# Altium schematic sheet S7CAS-PLEIADES-CARTE-MERE_P02_POWER.SchDoc
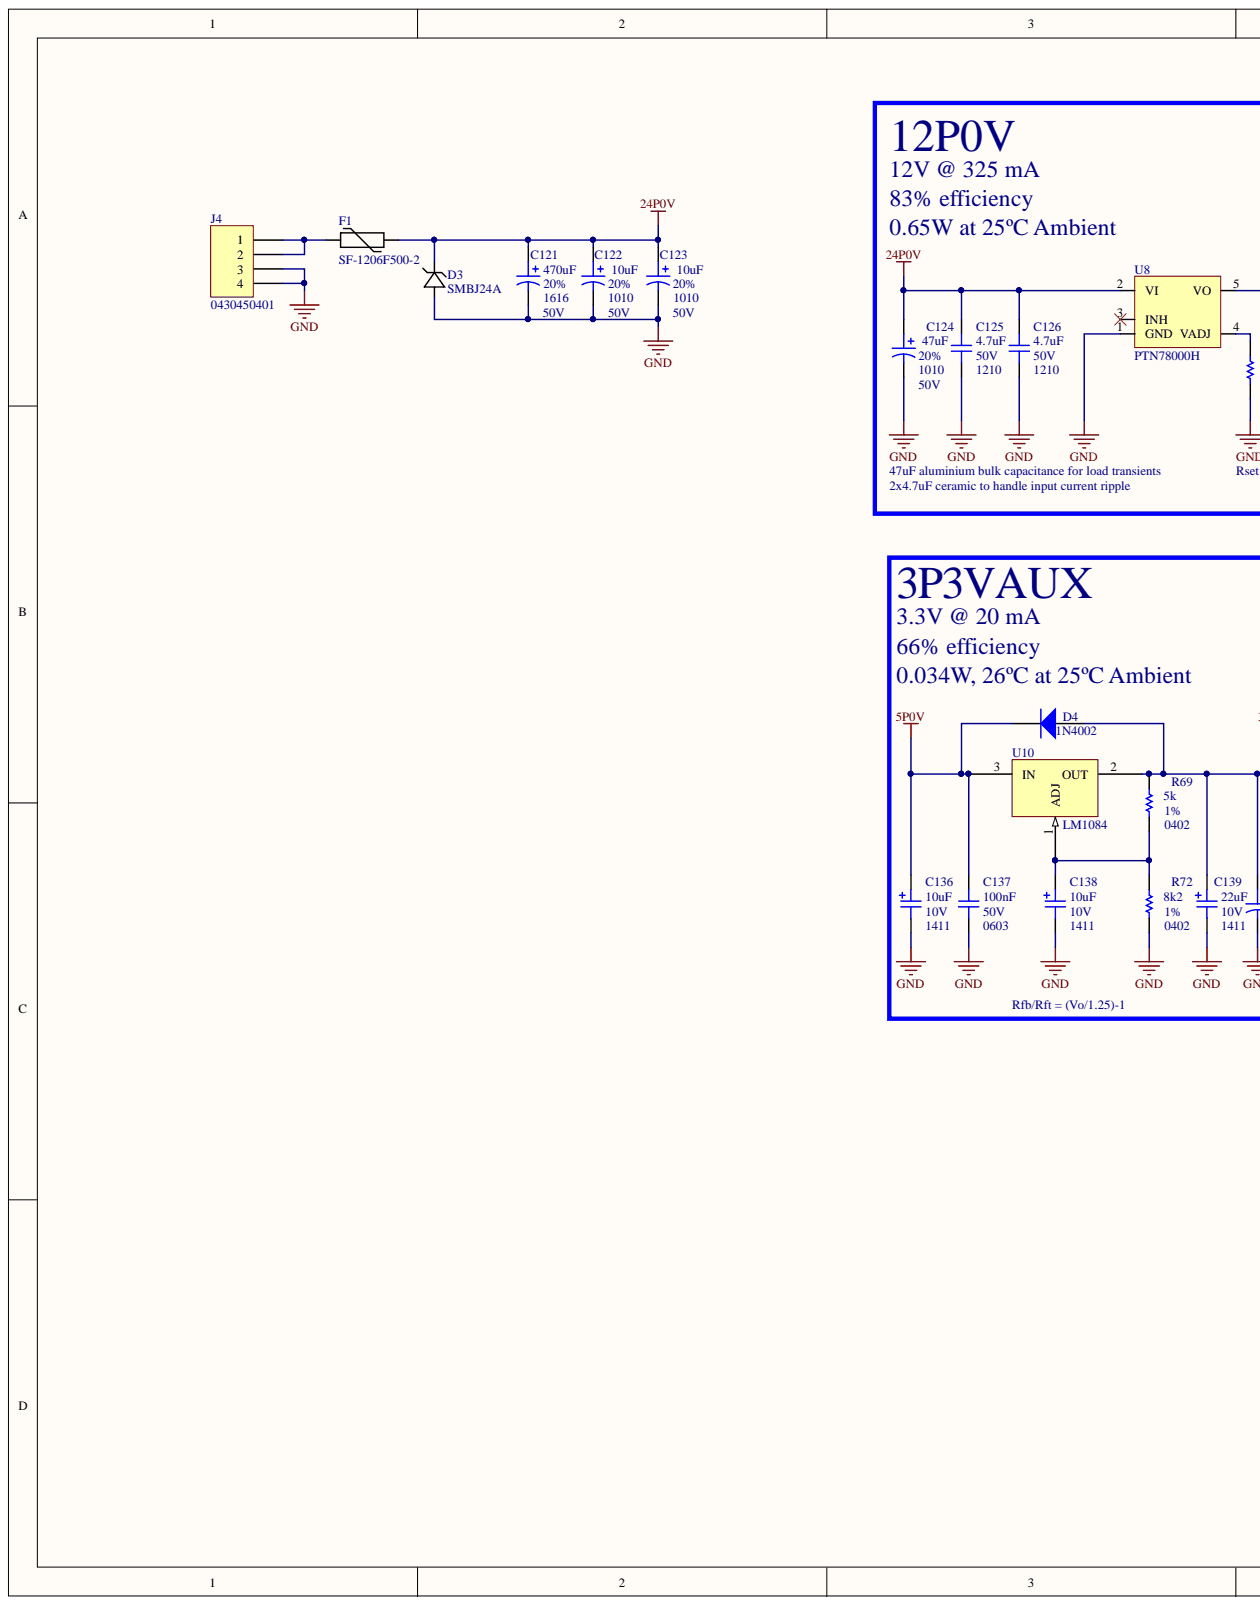
[diagram p0: S7CAS-PLEIADES-CARTE-MERE_P02_POWER.SchDoc, left]
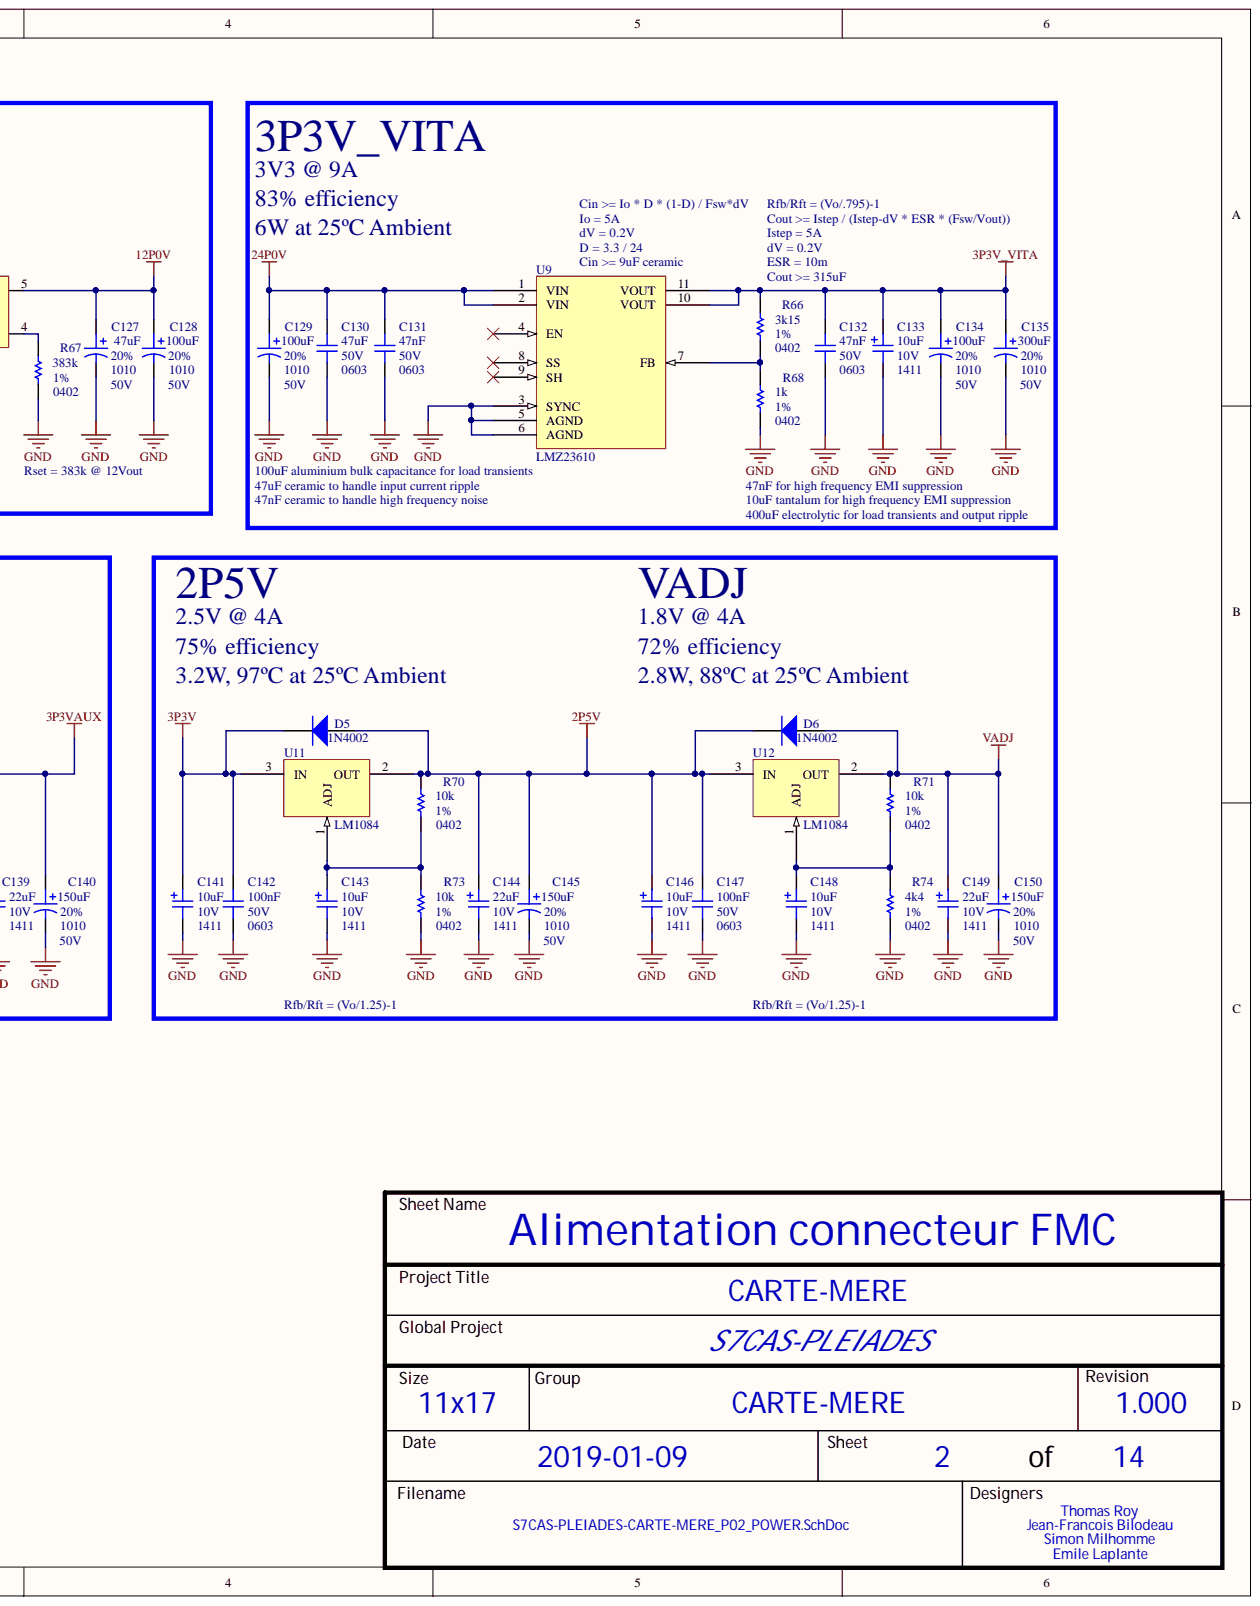
[diagram p1: S7CAS-PLEIADES-CARTE-MERE_P02_POWER.SchDoc, right]

## Parts
C121  value 470uF  Package: 1616  Precision: 20%  Voltage: 50V  [CAP_ALU_1616_vishay]  (hidden comment: MAL214699108E3)
C122  value 10uF  Package: 1010  Precision: 20%  Voltage: 50V  [CAP_ALU_1010_vishay]  (hidden comment: MAL215099101E3)
C123  value 10uF  Package: 1010  Precision: 20%  Voltage: 50V  [CAP_ALU_1010_vishay]  (hidden comment: MAL215099101E3)
C124  value 47uF  Package: 1010  Precision: 20%  Voltage: 50V  [CAP_ALU_1010_vishay]  (hidden comment: MAL215099101E3)
C125  value 4.7uF  Package: 1210  Voltage: 50V  [CAP_1210]  (hidden comment: CAP - 1210)
C126  value 4.7uF  Package: 1210  Voltage: 50V  [CAP_1210]  (hidden comment: CAP - 1210)
C127  value 47uF  Package: 1010  Precision: 20%  Voltage: 50V  [CAP_ALU_1010_vishay]  (hidden comment: MAL215099101E3)
C128  value 100uF  Package: 1010  Precision: 20%  Voltage: 50V  [CAP_ALU_1010_vishay]  (hidden comment: MAL215099101E3)
C129  value 100uF  Package: 1010  Precision: 20%  Voltage: 50V  [CAP_ALU_1010_vishay]  (hidden comment: MAL215099101E3)
C130  value 47uF  Package: 0603  Voltage: 50V  [CAP_0603]  (hidden comment: CAP - 0603)
C131  value 47nF  Package: 0603  Voltage: 50V  [CAP_0603]  (hidden comment: CAP - 0603)
C132  value 47nF  Package: 0603  Voltage: 50V  [CAP_0603]  (hidden comment: CAP - 0603)
C133  value 10uF  Package: 1411  Voltage: 10V  [CAP_TAN_1411(B)]  (hidden comment: CAP - TANTALUM - CASE B)
C134  value 100uF  Package: 1010  Precision: 20%  Voltage: 50V  [CAP_ALU_1010_vishay]  (hidden comment: MAL215099101E3)
C135  value 300uF  Package: 1010  Precision: 20%  Voltage: 50V  [CAP_ALU_1010_vishay]  (hidden comment: MAL215099101E3)
C136  value 10uF  Package: 1411  Voltage: 10V  [CAP_TAN_1411(B)]  (hidden comment: CAP - TANTALUM - CASE B)
C137  value 100nF  Package: 0603  Voltage: 50V  [CAP_0603]  (hidden comment: CAP - 0603)
C138  value 10uF  Package: 1411  Voltage: 10V  [CAP_TAN_1411(B)]  (hidden comment: CAP - TANTALUM - CASE B)
C139  value 22uF  Package: 1411  Voltage: 10V  [CAP_TAN_1411(B)]  (hidden comment: CAP - TANTALUM - CASE B)
C140  value 150uF  Package: 1010  Precision: 20%  Voltage: 50V  [CAP_ALU_1010_vishay]  (hidden comment: MAL215099101E3)
C141  value 10uF  Package: 1411  Voltage: 10V  [CAP_TAN_1411(B)]  (hidden comment: CAP - TANTALUM - CASE B)
C142  value 100nF  Package: 0603  Voltage: 50V  [CAP_0603]  (hidden comment: CAP - 0603)
C143  value 10uF  Package: 1411  Voltage: 10V  [CAP_TAN_1411(B)]  (hidden comment: CAP - TANTALUM - CASE B)
C144  value 22uF  Package: 1411  Voltage: 10V  [CAP_TAN_1411(B)]  (hidden comment: CAP - TANTALUM - CASE B)
C145  value 150uF  Package: 1010  Precision: 20%  Voltage: 50V  [CAP_ALU_1010_vishay]  (hidden comment: MAL215099101E3)
C146  value 10uF  Package: 1411  Voltage: 10V  [CAP_TAN_1411(B)]  (hidden comment: CAP - TANTALUM - CASE B)
C147  value 100nF  Package: 0603  Voltage: 50V  [CAP_0603]  (hidden comment: CAP - 0603)
C148  value 10uF  Package: 1411  Voltage: 10V  [CAP_TAN_1411(B)]  (hidden comment: CAP - TANTALUM - CASE B)
C149  value 22uF  Package: 1411  Voltage: 10V  [CAP_TAN_1411(B)]  (hidden comment: CAP - TANTALUM - CASE B)
C150  value 150uF  Package: 1010  Precision: 20%  Voltage: 50V  [CAP_ALU_1010_vishay]  (hidden comment: MAL215099101E3)
D3  SMBJ24A  [DO-214AA]
D4  1N4002  [DO-41]
D5  1N4002  [DO-41]
D6  1N4002  [DO-41]
F1  SF-1206F500-2  [1206]
J4  0430450401  [0430450401]
R66  value 3k15  Package: 0402  Precision: 1%  [RES_0402]  (hidden comment: RES - 0402)
R67  value 383k  Package: 0402  Precision: 1%  [RES_0402]  (hidden comment: RES - 0402)
R68  value 1k  Package: 0402  Precision: 1%  [RES_0402]  (hidden comment: RES - 0402)
R69  value 5k  Package: 0402  Precision: 1%  [RES_0402]  (hidden comment: RES - 0402)
R70  value 10k  Package: 0402  Precision: 1%  [RES_0402]  (hidden comment: RES - 0402)
R71  value 10k  Package: 0402  Precision: 1%  [RES_0402]  (hidden comment: RES - 0402)
R72  value 8k2  Precision: 1%  [RES_0402]  (hidden comment: RES - 0402)
R73  value 10k  Precision: 1%  [RES_0402]  (hidden comment: RES - 0402)
R74  value 4k4  Precision: 1%  [RES_0402]  (hidden comment: RES - 0402)
U8  PTN78000H  [PTN78000W]
U9  LMZ23610  [NDY0011A]
U10  LM1084  [LM1084-DPAK]
U11  LM1084  [LM1084-DPAK]
U12  LM1084  [LM1084-DPAK]

## Nets
2P5V: C144.2 C145.1 C146.2 C147.2 D5.1 D6.2 R70.2 U11.2 U12.3
3P3V: C141.2 C142.2 D5.2 U11.3
3P3V_VITA: C132.2 C133.2 C134.1 C135.1 R66.2 U9.10(VOUT) U9.11(VOUT)
3P3VAUX: C139.2 C140.1 D4.1 R69.2 U10.2
5P0V: C136.2 C137.2 D4.2 U10.3
12P0V: C127.1 C128.1 U8.5(VO)
24P0V: C121.1 C122.1 C123.1 C124.1 C125.2 C126.2 C129.1 C130.2 C131.2 D3.1 F1.2 U8.2(VI) U9.1(VIN) U9.2(VIN)
GND: C121.2 C122.2 C123.2 C124.2 C125.1 C126.1 C127.2 C128.2 C129.2 C130.1 C131.1 C132.1 C133.1 C134.2 C135.2 C136.1 C137.1 C138.1 C139.1 C140.2 C141.1 C142.1 C143.1 C144.1 C145.2 C146.1 C147.1 C148.1 C149.1 C150.2 D3.2 J4.3 J4.4 R67.1 R68.1 R72.1 R73.1 R74.1 U8.1(GND) U9.3(SYNC) U9.5(AGND) U9.6(AGND)
VADJ: C149.2 C150.1 D6.1 R71.2 U12.2
NetC138_2: C138.2 R69.1 R72.2 U10.1
NetC143_2: C143.2 R70.1 R73.2 U11.1
NetC148_2: C148.2 R71.1 R74.2 U12.1
NetF1_1: F1.1 J4.1 J4.2
NetR66_1: R66.1 R68.2 U9.7(FB)
NetR67_2: R67.2 U8.4(VADJ)

Not connected: U8 3(INH); U9 4(EN) 8(SS) 9(SH)

## Library data of the parts
C121 = CAP_ALU_MAL214699108E3: CAP - ALU 470uF 20% 50V - 1616; Current: 1.3A; Mfg: Vishay Dale; Component Kind: Standard; HelpURL: http://www.vishay.com/docs/28403/146cti.pdf; Library Name: S7CAS_Lib2019_REV1_00.IntLib; Mfg Part Number: MAL214699108E3; Supplier 1: Digikey; Supplier Part Number 1: 4442PHCT-ND; Temperature: -55°C to 125°C; CreatedBy: Jonathan Bouchard
C122 C123 C128 C129 C134 C135 C140 C145 C150 = CAP_ALU_MAL215099101E3: CAP - ALU 100uF 20% 50V - 1010; Current: 490mA; Mfg: Vishay Dale; Component Kind: Standard; HelpURL: http://www.vishay.com/docs/28395/150crz.pdf; Library Name: S7CAS_Lib2019_REV1_00.IntLib; Mfg Part Number: MAL215099101E3; Supplier 1: Digikey; Supplier Part Number 1: 4399PHDKR-ND; Temperature: -55°C to 125°C; CreatedBy: Jonathan Bouchard
C124 C127 = CAP_ALU_MAL215099101E3: CAP - ALU 47uF 20% 50V - 1010; Current: 490mA; Mfg: Vishay Dale; Component Kind: Standard; HelpURL: http://www.vishay.com/docs/28395/150crz.pdf; Library Name: S7CAS_Lib2019_REV1_00.IntLib; Mfg Part Number: MAL215099101E3; Supplier 1: Digikey; Supplier Part Number 1: 4399PHDKR-ND; Temperature: -55°C to 125°C; CreatedBy: Jonathan Bouchard
C125 C126 = CAP - 1210: Precision: 10%; Component Kind: Standard; Description: CAP - 1210; Library Name: S7CAS_Lib2019_REV1_00.IntLib; CreatedBy: Jonathan Bouchard
C130-C132 C137 C142 C147 = CAP - 0603: Precision: 10%; Component Kind: Standard; Description: CAP - 0603; Library Name: S7CAS_Lib2019_REV1_00.IntLib; CreatedBy: Jonathan Bouchard
C133 C136 C138 C139 C141 C143 C144 C146 C148 C149 = CAP_TANT_1411(B): CAP - TANTALUM - 1411; Precision: 10%; Component Kind: Standard; Library Name: S7CAS_Lib2019_REV1_00.IntLib; CreatedBy: Jonathan Bouchard
D3 = SMBJ24A: Imax: 15.5A; Pd: 600W; Vf: 24V; Component Kind: Standard; Library Name: TempLibrary.SchLib
D4-D6 = Diode 1N4002: 1 Amp General Purpose Rectifier; Code_JEDEC: DO-204-AL; Component Kind: Standard; ComponentLink1Description: Manufacturer Link; ComponentLink1URL: http://www.fairchildsemi.com/; ComponentLink2Description: Datasheet; ComponentLink2URL: http://www.fairchildsemi.com/ds/1N%2F1N4001.pdf; DatasheetVersion: 1998; LatestRevisionDate: 17-Jul-2002; LatestRevisionNote: Re-released for DXP Platform.; Library Name: Miscellaneous Devices.IntLib; PackageDescription: Diode, Axial; Body 5.2 x 2.7 mm (LxDia. max), Lead Dia. 0.86 mm (max); PackageReference: DO-41; PackageVersion: Aug-1999; Published: 8-Jun-2000; Signal Integrity: 1N4002; Simulation: 1N4002; Publisher: Altium Limited
F1 = SF-1206F500-2: Manufacturer: Bourns Inc.; Itrip: 5A; Voltage: 24V; Component Kind: Standard; Library Name: TempLibrary.SchLib; Part Number: SF-1206F500-2
J4 = Header 4: Header, 4-Pin; Manufacturer: Molex; Imax: 8A; Component Kind: Standard; LatestRevisionDate: 17-Jul-2002; LatestRevisionNote: Re-released for DXP Platform.; Library Name: Miscellaneous Connectors.IntLib; Part Number: 0430450401; Signal Integrity: Connector; Publisher: Altium Limited
R66 R68-R74 = RES - 0402: Power: 1/16W; Voltage: 50V; Component Kind: Standard; Description: RES - 0402; Library Name: S7CAS_Lib2019_REV1_00.IntLib; CreatedBy: Jonathan Bouchard
  R72-R74: Package: 0402
R67 = RES - 0402: RES - 0402 - 1/16W; Voltage: 50V; Component Kind: Standard; Library Name: S7CAS_Lib2019_REV1_00.IntLib; Power: 1/16W; CreatedBy: Jonathan Bouchard
U8 = PTN78000H: XXX - 1.5-A,  WIDE-INPUT  ADJUSTABLE  SWITCHING  REGULATOR - Package; GRAMS Lib Spec: 2.7; Component Kind: Standard; Library Name: lib_Thom.SchLib
U9 = LMZ23610: Component Kind: Standard; Library Name: lib_JF.SchLib
U10-U12 = LM1084: Component Kind: Standard; Library Name: lib_JF.SchLib
